# Altium schematic sheet BlazeBus_2.SchDoc
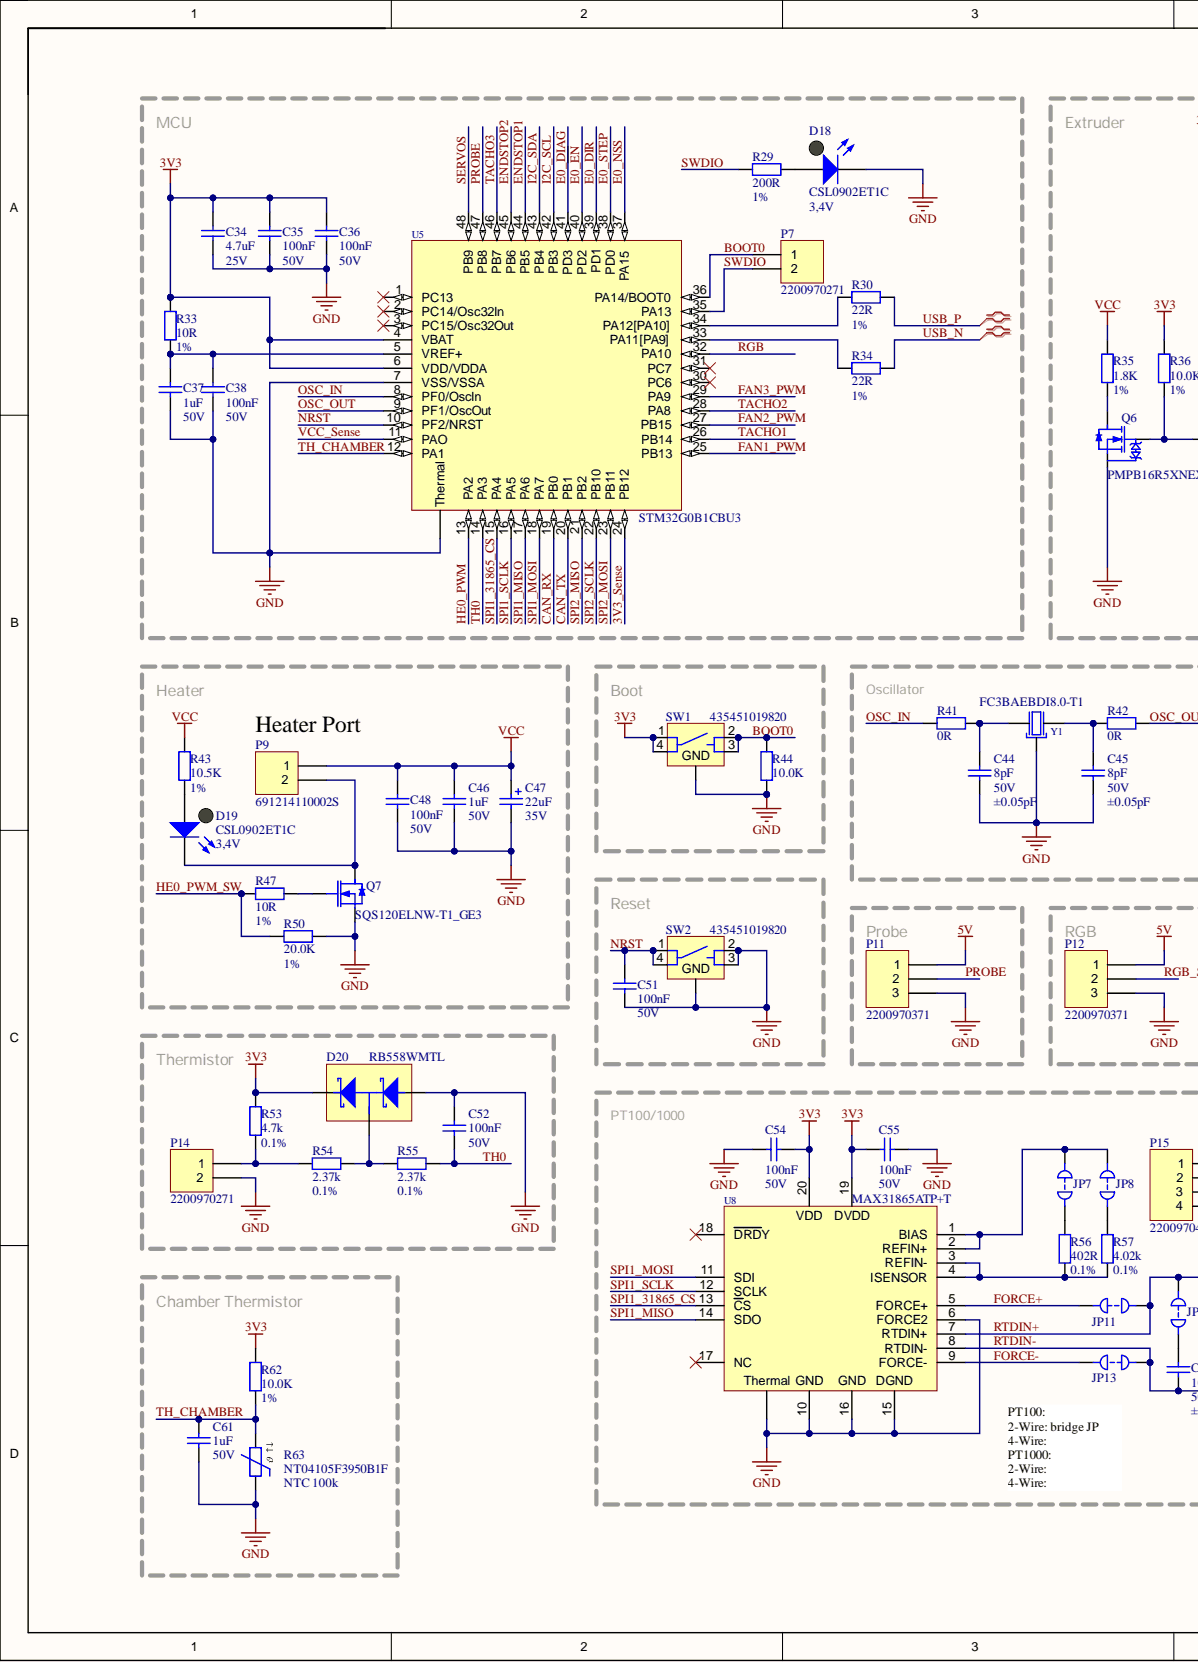
[diagram p0: BlazeBus_2.SchDoc, left]
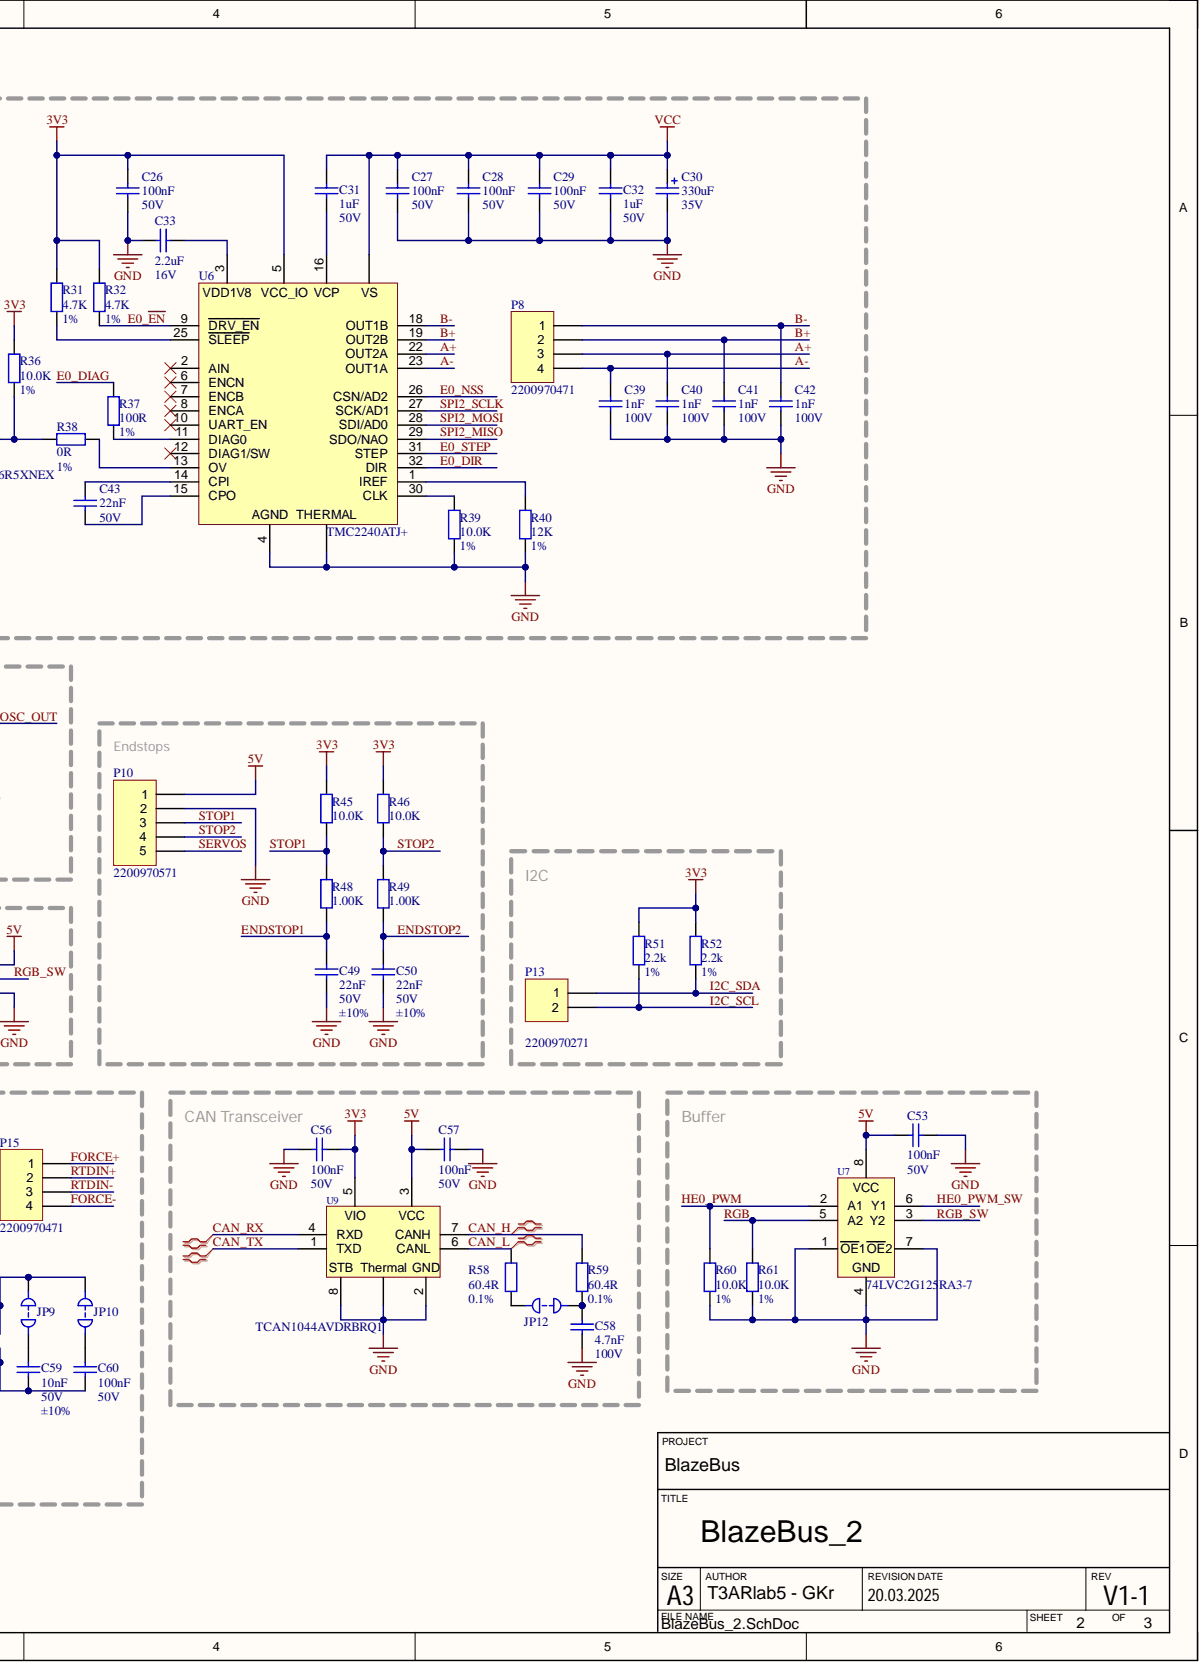
[diagram p1: BlazeBus_2.SchDoc, right]

## Parts
C26  C  value 100nF  Voltage Rating: 50V  [C_0402]
C27  C  value 100nF  Voltage Rating: 50V  [C_0402]
C28  C  value 100nF  Voltage Rating: 50V  [C_0402]
C29  C  value 100nF  Voltage Rating: 50V  [C_0402]
C30  value 330uF  Voltage Rating: 35V  [C_10-11.7_THT]  (hidden comment: C_Pol)
C31  C  value 1uF  Voltage Rating: 50V  [C_0603]
C32  C  value 1uF  Voltage Rating: 50V  [C_0603]
C33  C  value 2.2uF  [C_0603]
C34  C  value 4.7uF  Voltage Rating: 25V  [C_0805]
C35  C  value 100nF  Voltage Rating: 50V  [C_0402]
C36  C  value 100nF  Voltage Rating: 50V  [C_0402]
C37  C  value 1uF  Voltage Rating: 50V  [C_0603]
C38  C  value 100nF  Voltage Rating: 50V  [C_0402]
C39  C  value 1nF  Voltage Rating: 100V  [C_0402]
C40  C  value 1nF  Voltage Rating: 100V  [C_0402]
C41  C  value 1nF  Voltage Rating: 100V  [C_0402]
C42  C  value 1nF  Voltage Rating: 100V  [C_0402]
C43  C  value 22nF  Voltage Rating: 50V  [C_0402]
C44  C  value 8pF  Tolerance: ±0.05pF  Voltage Rating: 50V  [C_0402]
C45  C  value 8pF  Tolerance: ±0.05pF  Voltage Rating: 50V  [C_0402]
C46  C  value 1uF  Voltage Rating: 50V  [C_0805]
C47  value 22uF  Voltage Rating: 35V  [C_5.0-5.8]  (hidden comment: C_Pol)
C48  C  value 100nF  Voltage Rating: 50V  [C_0402]
C49  C  value 22nF  Tolerance: ±10%  Voltage Rating: 50V  [C_0402]
C50  C  value 22nF  Tolerance: ±10%  Voltage Rating: 50V  [C_0402]
C51  C  value 100nF  Voltage Rating: 50V  [C_0402]
C52  C  value 100nF  Voltage Rating: 50V  [C_0402]
C53  C  value 100nF  [C_0402]
C54  C  value 100nF  [C_0402]
C55  C  value 100nF  [C_0402]
C56  C  value 100nF  [C_0402]
C57  C  value 100nF  [C_0402]
C58  C  value 4.7nF  Voltage Rating: 100V  [C_0402]
C59  C  value 10nF  Tolerance: ±10%  Voltage Rating: 50V  [C_0402]
C60  C  value 100nF  Tolerance: ±10%  Voltage Rating: 50V  [C_0402]
C61  C  value 1uF  Voltage Rating: 50V  [C_0603]
D18  Manufacturer ID: CSL0902ET1C  Voltage Rating: 3,4V  [LED_0603_green_Rohm]  (hidden comment: LED_green)
D19  Manufacturer ID: CSL0902ET1C  Voltage Rating: 3,4V  [LED_0603_green_Rohm]  (hidden comment: LED_green)
D20  Manufacturer ID: RB558WMTL  [SOT-490]  (hidden comment: D_Schottky_Dual)
JP7  Jumper  [R_0402 Jumper]  (value not drawn)
JP8  Jumper  [R_0402 Jumper]  (value not drawn)
JP9  Jumper  [R_0402 Jumper]  (value not drawn)
JP10  Jumper  [R_0402 Jumper]  (value not drawn)
JP11  Jumper  [R_0402 Jumper]  (value not drawn)
JP12  Jumper  [R_0402 Jumper]  (value not drawn)
JP13  Jumper  [R_0402 Jumper]  (value not drawn)
P7  Manufacturer ID: 2200970271  [Micro-Lock_Plus-2]  (hidden comment: Header 2)
P8  Manufacturer ID: 2200970471  [Micro-Lock_Plus-4]  (hidden comment: Header 4)
P9  Manufacturer ID: 691214110002S  [WR-TBL-2]  (hidden comment: Header 2)
P10  Manufacturer ID: 2200970571  [Micro-Lock_Plus-5]  (hidden comment: Header 5)
P11  Manufacturer ID: 2200970371  [Micro-Lock_Plus-3]  (hidden comment: Header 3)
P12  Manufacturer ID: 2200970371  [Micro-Lock_Plus-3]  (hidden comment: Header 3)
P13  Manufacturer ID: 2200970271  [Micro-Lock_Plus-2]  (hidden comment: Header 2)
P14  Manufacturer ID: 2200970271  [Micro-Lock_Plus-2]  (hidden comment: Header 2)
P15  Manufacturer ID: 2200970471  [Micro-Lock_Plus-4]  (hidden comment: Header 4)
Q6  Manufacturer ID: PMPB16R5XNEX  [DFN2020M-6]  (hidden comment: MOSFET-N_GDS)
Q7  Manufacturer ID: SQS120ELNW-T1_GE3  [PowerPAK-1212-8SLW]  (hidden comment: MOSFET-N-Depletion-SSSGDDDD)
R29  R  value 200R  Tolerance: 1%  [R_0402]
R30  R  value 22R  Tolerance: 1%  [R_0402]
R31  R  value 4.7K  Tolerance: 1%  [R_0402]
R32  R  value 4.7K  Tolerance: 1%  [R_0402]
R33  R  value 10R  Tolerance: 1%  [R_0402]
R34  R  value 22R  Tolerance: 1%  [R_0402]
R35  R  value 1.8K  Tolerance: 1%  [R_0805]
R36  R  value 10.0K  Tolerance: 1%  [R_0402]
R37  R  value 100R  Tolerance: 1%  [R_0402]
R38  R  value 0R  [R_0402]
R39  R  value 10.0K  Tolerance: 1%  [R_0402]
R40  R  value 12K  Tolerance: 1%  [R_0402]
R41  R  value 0R  [R_0402]
R42  R  value 0R  [R_0402]
R43  R  value 10.5K  Tolerance: 1%  [R_0402]
R44  R  value 10.0K  [R_0402]
R45  R  value 10.0K  [R_0402]
R46  R  value 10.0K  [R_0402]
R47  R  value 10R  [R_0402]
R48  R  value 1.00K  [R_0402]
R49  R  value 1.00K  [R_0402]
R50  R  value 20.0K  Tolerance: 1%  [R_0402]
R51  R  value 2.2k  Tolerance: 1%  [R_0402]
R52  R  value 2.2k  Tolerance: 1%  [R_0402]
R53  R  value 4.7k  Tolerance: 0.1%  [R_0402]
R54  R  value 2.37k  Tolerance: 0.1%  [R_0402]
R55  R  value 2.37k  Tolerance: 0.1%  [R_0402]
R56  R  value 402R  Tolerance: 0.1%  [R_0402]
R57  R  value 4.02k  Tolerance: 0.1%  [R_0402]
R58  R  value 60.4R  [R_0402]
R59  R  value 60.4R  Tolerance: 0.1%  [R_0402]
R60  R  value 10.0K  Tolerance: 1%  [R_0402]
R61  R  value 10.0K  Tolerance: 1%  [R_0402]
R62  R  value 10.0K  Tolerance: 1%  [R_0402]
R63  R  Manufacturer ID: NT04105F3950B1F  [R_0402]
SW1  Switch-1x NO  [435451019820]  (value not drawn)
SW2  Switch-1x NO  [435451019820]  (value not drawn)
U5  STM32G0B1C  Manufacturer ID: STM32G0B1CBU3  [UFQFPN48]
U6  TMC2240  Manufacturer ID: TMC2240ATJ+  [TQFN-32]
U7  74LVC2G125  Manufacturer ID: 74LVC2G125RA3-7  [DFN1210-8]
U8  MAX31865  Manufacturer ID: MAX31865ATP+T  [TQFN-20]
U9  TCAN1044AV  Manufacturer ID: TCAN1044AVDRBRQ1  [SON-8]
Y1  Manufacturer ID: FC3BAEBDI8.0-T1  [4-SMD 3.2x2.5-Abracon]  (hidden comment: Crystal 4-Pin)

## Nets
3V3: C26.2 C34.2 C35.2 C36.2 C54.2 C55.1 C56.2 D20.2 R31.2 R32.2 R33.2 R36.2 R45.2 R46.2 R51.2 R52.2 R53.2 R62.2 SW1.1 SW1.4 U5.4(VBAT) U5.6(VDD/VDDA) U6.5 U8.19 U8.20 U9.5
5V: C53.2 C57.2 P10.1 P11.1 P12.1 U7.8 U9.3
GND: C26.1 C27.1 C28.1 C29.1 C30.2 C32.1 C33.1 C34.1 C35.1 C36.1 C37.1 C38.1 C39.1 C40.1 C41.1 C42.1 C44.1 C45.1 C46.1 C47.2 C48.1 C49.1 C50.1 C51.1 C52.2 C53.1 C54.1 C55.2 C56.1 C57.1 C58.1 C61.1 D18.K D20.1 P10.2 P11.3 P12.3 P14.2 Q6.4,8 Q7.1,2,3 R39.2 R40.1 R44.1 R50.2 R60.1 R61.2 R63.1 SW1.5 SW2.2 SW2.3 SW2.5 U5.7(VSS/VSSA) U5.Thermal U6.4 U6.THERMAL U7.1(OE1) U7.4 U7.7(OE2) U8.6(FORCE2) U8.10 U8.15 U8.16 U8.Thermal U9.2 U9.8 U9.Thermal Y1.2,4
VCC: C27.2 C28.2 C29.2 C30.1 C31.2 C32.2 C46.2 C47.1 C48.2 P9.1 R35.2 R43.2 U6.17,20,21,24(VS)
3V3_Sense: U5.24(PB12)
A+: C40.2 P8.3 U6.22(OUT2A)
A-: C39.2 P8.4 U6.23(OUT1A)
B+: C41.2 P8.2 U6.19(OUT2B)
B-: C42.2 P8.1 U6.18(OUT1B)
BOOT0: P7.1 R44.2 SW1.2 SW1.3 U5.36(PA14/BOOT0)
CAN_H: R59.1 U9.7(CANH)
CAN_L: R58.1 U9.6(CANL)
CAN_RX: U5.19(PB0) U9.4(RXD)
CAN_TX: U5.20(PB1) U9.1(TXD)
E0_DIAG: R37.1 U5.41(PD3)
E0_DIR: U5.39(PD1) U6.32(DIR)
E0_~{EN}: R32.1 U5.40(PD2) U6.9(DRV_EN)
E0_NSS: U5.37(PA15) U6.26(CSN/AD2)
E0_STEP: U5.38(PD0) U6.31(STEP)
ENDSTOP1: C49.2 R48.1 U5.44(PB5)
ENDSTOP2: C50.2 R49.1 U5.45(PB6)
FAN1_PWM: U5.25(PB13)
FAN2_PWM: U5.27(PB15)
FAN3_PWM: U5.29(PA9)
FORCE+: JP11.2 P15.1 U8.5(FORCE+)
FORCE-: JP13.1 P15.4 U8.9(FORCE-)
HE0_PWM: R60.2 U5.13(PA2) U7.2(A1)
HE0_PWM_SW: R47.1 R50.1 U7.6(Y1)
I2C_SCL: P13.2 R51.1 U5.42(PB3)
I2C_SDA: P13.1 R52.1 U5.43(PB4)
NRST: C51.2 SW2.1 SW2.4 U5.10(PF2/NRST)
OSC_IN: R41.1 U5.8(PF0/OscIn)
OSC_OUT: R42.2 U5.9(PF1/OscOut)
PROBE: P11.2 U5.47(PB8)
RGB: R61.1 U5.32(PA10) U7.5(A2)
RGB_SW: P12.2 U7.3(Y2)
RTDIN+: JP9.2 JP10.2 JP11.1 P15.2 U8.7(RTDIN+)
RTDIN-: C59.1 C60.1 JP13.2 P15.3 U8.8(RTDIN-)
SERVOS: P10.5 U5.48(PB9)
SPI1_31865_CS: U5.15(PA4) U8.13(CS)
SPI1_MISO: U5.17(PA6) U8.14(SDO)
SPI1_MOSI: U5.18(PA7) U8.11(SDI)
SPI1_SCLK: U5.16(PA5) U8.12(SCLK)
SPI2_MISO: U5.21(PB2) U6.29(SDO/NAO)
SPI2_MOSI: U5.23(PB11) U6.28(SDI/AD0)
SPI2_SCLK: U5.22(PB10) U6.27(SCK/AD1)
STOP1: P10.3 R45.1 R48.2
STOP2: P10.4 R46.1 R49.2
SWDIO: P7.2 R29.2 U5.35(PA13)
TACHO1: U5.26(PB14)
TACHO2: U5.28(PA8)
TACHO3: U5.46(PB7)
TH0: C52.1 R55.2 U5.14(PA3)
TH_CHAMBER: C61.2 R62.1 R63.2 U5.12(PA1)
USB_N: R34.2
USB_P: R30.2
VCC_Sense: U5.11(PAO)
NetC31_1: C31.1 U6.16
NetC33_2: C33.2 U6.3
NetC37_2: C37.2 C38.2 R33.1 U5.5(VREF+)
NetC43_1: C43.1 U6.14(CPI)
NetC43_2: C43.2 U6.15(CPO)
NetC44_2: C44.2 R41.2 Y1.1
NetC45_2: C45.2 R42.1 Y1.3
NetC58_2: C58.2 JP12.2 R59.2
NetC59_2: C59.2 JP9.1
NetC60_2: C60.2 JP10.1
NetD18_A: D18.A R29.1
NetD19_A: D19.A R43.1
NetD19_K: D19.K P9.2 Q7.5,6,7,8
NetD20_3: D20.3 R54.2 R55.1
NetJP7_1: JP7.1 R56.2
NetJP7_2: JP7.2 JP8.2 U8.1(BIAS) U8.2(REFIN+)
NetJP8_1: JP8.1 R57.2
NetJP12_1: JP12.1 R58.2
NetP14_1: P14.1 R53.1 R54.1
NetQ6_1,2,5,6,7: Q6.1,2,5,6,7 R35.1
NetQ6_3: Q6.3 R36.1 R38.1
NetQ7_4: Q7.4 R47.2
NetR30_1: R30.1 U5.34(PA12[PA10])
NetR31_1: R31.1 U6.25(SLEEP)
NetR34_1: R34.1 U5.33(PA11[PA9])
NetR37_2: R37.2 U6.11(DIAG0)
NetR38_2: R38.2 U6.13(OV)
NetR39_1: R39.1 U6.30(CLK)
NetR40_2: R40.2 U6.1(IREF)
NetR56_1: R56.1 R57.1 U8.3(REFIN-) U8.4(ISENSOR)

Not connected: U5 1(PC13) 2(PC14/Osc32In) 3(PC15/Osc32Out) 30(PC6) 31(PC7); U6 2(AIN) 6(ENCN) 7(ENCB) 8(ENCA) 10(UART_EN) 12(DIAG1/SW); U8 17(NC) 18(DRDY)

## Library data of the parts
C26-C29 C35 C36 C38 C48 C51-C57 C60 = 02_0122: Capacitor 100nF 50V ±10% 0402 Ceramic SMD; Manufacturer: Yageo; Database Table Name: 02_Capacitors$; Temperature Coefficient: X7R; Component Kind: Standard; Library Name: Library_DBLib.DbLib; Mounting Style: SMD; Part ID: 02_0122; Temperature Range: -55°C to +125°C; Type: Ceramic
  C26-C29 C35 C36 C38 C48 C51 C52: Tolerance: ±10%
  C53-C57: Tolerance: ±10%; Voltage Rating: 50V
C30 = 02_0937: Capacitor 330uF 35V ±20% 10-11.7_THT Aluminium Polymer THT; Manufacturer: Panasonic; Manufacturer ID: EEH-AZS1V331B; Database Table Name: 02_Capacitors$; Component Kind: Standard; Digikey ID: 10-EEH-AZS1V331BCT-ND; LCSC ID: C7182683; Library Name: Library_DBLib.DbLib; Mounting Style: THT; Mouser ID: 667-EEH-AZS1V331B; Part ID: 02_0937; Revision: July-2002: Re-released for DXP Platform.; Signal Integrity: Cap Pol; Simulation: CAP; Temperature Range: -55°C to +135°C; Tolerance: ±20%; Type: Aluminium Polymer
C31 C32 C37 C61 = 02_0270: Capacitor 1uF 50V ±10% 0603 Ceramic SMD; Manufacturer: Yageo; Database Table Name: 02_Capacitors$; Temperature Coefficient: X7R; Component Kind: Standard; Library Name: Library_DBLib.DbLib; Mounting Style: SMD; Part ID: 02_0270; Temperature Range: -55°C to +125°C; Tolerance: ±10%; Type: Ceramic
C33 = 02_0185: Capacitor 2.2uF 16V ±10% 0603 Ceramic SMD; Manufacturer: Yageo; Database Table Name: 02_Capacitors$; Temperature Coefficient: X7R; Component Kind: Standard; Library Name: Library_DBLib.DbLib; Mounting Style: SMD; Part ID: 02_0185; Temperature Range: -55°C to +125°C; Tolerance: ±10%; Type: Ceramic; Voltage Rating: 16V
C34 = 02_0375: Capacitor 4.7uF 25V ±10% 0805 Ceramic SMD; Manufacturer: Yageo; Database Table Name: 02_Capacitors$; Temperature Coefficient: X7R; Component Kind: Standard; Library Name: Library_DBLib.DbLib; Mounting Style: SMD; Part ID: 02_0375; Temperature Range: -55°C to +125°C; Tolerance: ±10%; Type: Ceramic
C39-C42 = 02_0127: Capacitor 1nF 100V ±10% 0402 Ceramic SMD; Manufacturer: Yageo; Database Table Name: 02_Capacitors$; Temperature Coefficient: X7R; Component Kind: Standard; Library Name: Library_DBLib.DbLib; Mounting Style: SMD; Part ID: 02_0127; Temperature Range: -55°C to +125°C; Tolerance: ±10%; Type: Ceramic
C43 C49 C50 = 02_0117: Capacitor 22nF 50V ±10% 0402 Ceramic SMD; Manufacturer: Yageo; Database Table Name: 02_Capacitors$; Temperature Coefficient: X7R; Component Kind: Standard; Library Name: Library_DBLib.DbLib; Mounting Style: SMD; Part ID: 02_0117; Temperature Range: -55°C to +125°C; Type: Ceramic
  C43: Tolerance: ±10%
C44 C45 = 02_0938: Capacitor 8pF 50V ±0.05pF 0402 Ceramic SMD; Manufacturer: Yageo; Manufacturer ID: CQ0402ARNPO9BN8R0; Database Table Name: 02_Capacitors$; Temperature Coefficient: NP0; Component Kind: Standard; Digikey ID: 13-CQ0402ARNPO9BN8R0CT-ND; LCSC ID: C3849295; Library Name: Library_DBLib.DbLib; Mounting Style: SMD; Mouser ID: 603-CQ402ARNPO9BN8R0; Part ID: 02_0938; Temperature Range: -55°C to +125°C; Type: Ceramic
C46 = 02_0424: Capacitor 1uF 50V ±10% 0805 Ceramic SMD; Manufacturer: Yageo; Database Table Name: 02_Capacitors$; Temperature Coefficient: X7R; Component Kind: Standard; Library Name: Library_DBLib.DbLib; Mounting Style: SMD; Part ID: 02_0424; Temperature Range: -55°C to +125°C; Tolerance: ±10%; Type: Ceramic
C47 = 02_0936: Capacitor 22uF 35V ±20% 5.0-5.8 Aluminium Polymer SMD; Manufacturer: Panasonic; Manufacturer ID: EEH-ZC1V220R; Database Table Name: 02_Capacitors$; Component Kind: Standard; Digikey ID: P15471CT-ND; LCSC ID: C341197; Library Name: Library_DBLib.DbLib; Mounting Style: SMD; Mouser ID: 667-EEH-ZC1V220R; Part ID: 02_0936; Revision: July-2002: Re-released for DXP Platform.; Signal Integrity: Cap Pol; Simulation: CAP; Temperature Range: -55°C to +125°C; Tolerance: ±20%; Type: Aluminium Polymer
C58 = 02_0129: Capacitor 4.7nF 100V ±10% 0402 Ceramic SMD; Manufacturer: Yageo; Database Table Name: 02_Capacitors$; Temperature Coefficient: X7R; Component Kind: Standard; Library Name: Library_DBLib.DbLib; Mounting Style: SMD; Part ID: 02_0129; Temperature Range: -55°C to +125°C; Tolerance: ±10%; Type: Ceramic
C59 = 02_0113: Capacitor 10nF 50V ±10% 0402 Ceramic SMD; Manufacturer: Yageo; Database Table Name: 02_Capacitors$; Temperature Coefficient: X7R; Component Kind: Standard; Library Name: Library_DBLib.DbLib; Mounting Style: SMD; Part ID: 02_0113; Temperature Range: -55°C to +125°C; Type: Ceramic
D18 D19 = 04_0004: LED SMD Green 1.2cd@20mA 0603; Manufacturer: Rohm; Current Rating: 30mA; Database Table Name: 04_Diodes$; Power Rating: 114mW; Type: LED Green; Component Kind: Standard; Digikey ID: 846-CSL0902ET1CCT-ND; LCSC ID: C5603524; Library Name: Library_DBLib.DbLib; Mouser ID: 755-CSL0902ET1C; Part ID: 04_0004; Temperature Range: -40°C to +110°C
D20 = 04_0010: Schottky Array; Manufacturer: Rohm; Code - JEDEC: DO-214-AA; Current Rating: 100mA, 0.5A Peak; Database Table Name: 04_Diodes$; Voltage Rating: 30V; Component Kind: Standard; Digikey ID: 846-RB558WMTLCT-ND; LCSC ID: C509944; Library Name: Library_DBLib.DbLib; Mouser ID: 755-RB558WMTL; Part ID: 04_0010; Published: 8-Jun-2000; Revision: July-2002: Re-released for DXP Platform.; Signal Integrity: D Schottky; Simulation: SKYDIODE; Temperature Range: -40°C to +125°C; Type: Schottky Array; Publisher: Altium Limited
JP7-JP13 = 07_0026: 0402 Jumper, solderable; Database Table Name: 07_Connectors$; Component Kind: Standard; Library Name: Library_DBLib.DbLib; Part ID: 07_0026; Type: 0402 Jumper, solderable
P7 P13 P14 = 07_0022: Micro-Lock Plus, 2Pin, THT, 1.50mm Pitch, Tin-Bismuth; Manufacturer: Molex; Current Rating: 3,3A; Database Table Name: 07_Connectors$; Voltage Rating: 50V; Component Kind: Standard; Digikey ID: 900-2200970271-ND; Library Name: Library_DBLib.DbLib; Mounting Style: THT; Mouser ID: 538-220097-0271; Orientation: Vertical; Part ID: 07_0022; Pitch: 1.50mm; Revision: 17-Jul-2002: Re-released for DXP Platform.; Signal Integrity: Connector; Temperature Range: -40°C to +105°C; Type: 2Pin Micro-Lock Plus Header; Publisher: Altium Limited
P8 P15 = 07_0024: Micro-Lock Plus, 4Pin, THT, 1.50mm Pitch, Tin-Bismuth; Manufacturer: Molex; Current Rating: 3,3A; Database Table Name: 07_Connectors$; Voltage Rating: 50V; Component Kind: Standard; Digikey ID: 900-2200970471-ND; Library Name: Library_DBLib.DbLib; Mounting Style: THT; Mouser ID: 538-220097-0471; Orientation: Vertical; Part ID: 07_0024; Pitch: 1.50mm; Revision: 17-Jul-2002: Re-released for DXP Platform.; Signal Integrity: Connector; Temperature Range: -40°C to +105°C; Type: 4Pin Micro-Lock Plus Header; Publisher: Altium Limited
P9 = 07_0012: WR-TBL, 2Pin, THT, 3.50mm Pitch; Manufacturer: Würth; Current Rating: 10A; Database Table Name: 07_Connectors$; Voltage Rating: 300V; Component Kind: Standard; Digikey ID: 732-691214110002S-ND; Library Name: Library_DBLib.DbLib; Mounting Style: THT; Mouser ID: 710-691214110002S; Orientation: Horizontal; Part ID: 07_0012; Pitch: 3.50mm; Revision: 17-Jul-2002: Re-released for DXP Platform.; Signal Integrity: Connector; Temperature Range: -30°C to +120°C; Type: 2Pin WR-TBL Screw Terminal; Publisher: Altium Limited
P10 = 07_0029: Micro-Lock Plus, 5Pin, THT, 1.50mm Pitch, Tin-Bismuth; Manufacturer: Molex; Current Rating: 3,3A; Database Table Name: 07_Connectors$; Voltage Rating: 50V; Component Kind: Standard; Digikey ID: 900-2200970571-ND; LCSC ID: C19803171; Library Name: Library_DBLib.DbLib; Mounting Style: THT; Mouser ID: 538-220097-0571; Orientation: Vertical; Part ID: 07_0029; Pitch: 1.50mm; Revision: 17-Jul-2002: Re-released for DXP Platform.; Signal Integrity: Connector; Temperature Range: -40°C to +105°C; Type: 5Pin Micro-Lock Plus Header; Publisher: Altium Limited
P11 P12 = 07_0023: Micro-Lock Plus, 3Pin, THT, 1.50mm Pitch, Tin-Bismuth; Manufacturer: Molex; Current Rating: 3,3A; Database Table Name: 07_Connectors$; Voltage Rating: 50V; Component Kind: Standard; Library Name: Library_DBLib.DbLib; Mounting Style: THT; Mouser ID: 538-220097-0371; Orientation: Vertical; Part ID: 07_0023; Pitch: 1.50mm; Revision: 17-Jul-2002: Re-released for DXP Platform.; Signal Integrity: Connector; Temperature Range: -40°C to +105°C; Type: 3Pin Micro-Lock Plus Header; Publisher: Altium Limited
Q6 = 05_0002: N-Channel Trench 30V 7A 1.7W; Manufacturer: Nexperia; Database Table Name: 05_Transistors$; Power Rating: 7.7W; Type: N-Mosfet; Component Kind: Standard; Current Rating: 7A; Digikey ID: 1727-PMPB16R5XNEXCT-ND; LCSC ID: C3277699; Library Name: Library_DBLib.DbLib; Mouser ID: 771-PMPB16R5XNEX; Part ID: 05_0002; Revision: July-2002: Re-released for DXP Platform.; Simulation: NMOS; Temperature Range: -55°C to +150°C; Voltage Rating: 30V
Q7 = 05_0001: N-Channel 30V 110A 39W; Manufacturer: Vishay; Database Table Name: 05_Transistors$; Type: N-Mosfet; Component Kind: Standard; Current Rating: 110A; Digikey ID: 742-SQS120ELNW-T1_GE3CT-ND; Library Name: Library_DBLib.DbLib; Mouser ID: 78-SQS120ELNW-T1_GE3; Part ID: 05_0001; Power Rating: 39W; Revision: July-2002: Re-released for DXP Platform.; Temperature Range: -55°C to +175°C; Voltage Rating: 30V
R29 = 01_0223: Resistor 200R 0402 1/16W 1%; Manufacturer: Yageo; Manufacturer ID: RC0402FR-07200RL; Current Rating: 1A; Database Table Name: 01_Resistors$; Temperature Coefficient: ± 100ppm/℃; Voltage Rating: 50V; Component Kind: Standard; Library Name: Library_DBLib.DbLib; Mouser ID: 603-RC0402FR-07200RL; Part ID: 01_0223; Power Rating: 1/16W; Temperature Range: -55°C to +155°C
R30 R34 = 01_2705: Resistor 22R 0402 1/16W 1%; Manufacturer: Yageo; Manufacturer ID: RC0402FR-0722RL; Current Rating: 1A; Database Table Name: 01_Resistors$; Temperature Coefficient: ± 100ppm/℃; Voltage Rating: 50V; Component Kind: Standard; Digikey ID: 311-22.0LRCT-ND; LCSC ID: C114765; Library Name: Library_DBLib.DbLib; Mouser ID: 603-RC0402FR-0722RL; Part ID: 01_2705; Power Rating: 1/16W; Temperature Range: -55°C to +155°C
R31 R32 = 01_2723: Resistor 4.7K 0402 1/16W 1%; Manufacturer: Yageo; Manufacturer ID: RC0402FR-074K7L; Current Rating: 1A; Database Table Name: 01_Resistors$; Temperature Coefficient: ± 100ppm/℃; Voltage Rating: 50V; Component Kind: Standard; Library Name: Library_DBLib.DbLib; Mouser ID: 603-RC0402FR-074K7L; Part ID: 01_2723; Power Rating: 1/16W; Temperature Range: -55°C to +155°C
R33 R47 = 01_0098: Resistor 10R 0402 1/16W 1%; Manufacturer: Yageo; Manufacturer ID: RC0402FR-0710RL; Current Rating: 1A; Database Table Name: 01_Resistors$; Temperature Coefficient: ± 200ppm/℃; Voltage Rating: 50V; Component Kind: Standard; Library Name: Library_DBLib.DbLib; Mouser ID: 603-RC0402FR-0710RL; Part ID: 01_0098; Power Rating: 1/16W; Temperature Range: -55°C to +155°C
  R47: Tolerance: 1%
R35 = 01_2851: Resistor 1.8K 0805 1/2W 1%; Manufacturer: Panasonic; Manufacturer ID: ERJ-P06F1801V; Database Table Name: 01_Resistors$; Temperature Coefficient: ± 100ppm/℃; Voltage Rating: 400V; Component Kind: Standard; Digikey ID: 10-ERJ-P06F1801VCT-ND; LCSC ID: C416985; Library Name: Library_DBLib.DbLib; Mouser ID: 667-ERJ-P06F1801V; Part ID: 01_2851; Power Rating: 1/2W; Temperature Range: -55°C to +155°C
R36 R39 R44-R46 R60-R62 = 01_0386: Resistor 10.0K 0402 1/16W 1%; Manufacturer: Yageo; Manufacturer ID: RC0402FR-0710KL; Current Rating: 1A; Database Table Name: 01_Resistors$; Temperature Coefficient: ± 100ppm/℃; Voltage Rating: 50V; Component Kind: Standard; Library Name: Library_DBLib.DbLib; Mouser ID: 603-RC0402FR-0710KL; Part ID: 01_0386; Power Rating: 1/16W; Temperature Range: -55°C to +155°C
  R44-R46: Tolerance: 1%
R37 = 01_0194: Resistor 100R 0402 1/16W 1%; Manufacturer: Yageo; Manufacturer ID: RC0402FR-07100RL; Current Rating: 1A; Database Table Name: 01_Resistors$; Temperature Coefficient: ± 100ppm/℃; Voltage Rating: 50V; Component Kind: Standard; Library Name: Library_DBLib.DbLib; Mouser ID: 603-RC0402FR-07100RL; Part ID: 01_0194; Power Rating: 1/16W; Temperature Range: -55°C to +155°C
R38 R41 R42 = 01_0001: Resistor 0R 0402 1/16W 1%; Manufacturer: Yageo; Manufacturer ID: RC0402FR-070RL; Current Rating: 1A; Database Table Name: 01_Resistors$; Temperature Coefficient: ± 200ppm/℃; Voltage Rating: 50V; Component Kind: Standard; Library Name: Library_DBLib.DbLib; Mouser ID: 603-RC0402FR-070RL; Part ID: 01_0001; Power Rating: 1/16W; Temperature Range: -55°C to +155°C; Tolerance: 1%
R40 = 01_2847: Resistor 12K 0402 1/16W 1%; Manufacturer: Yageo; Manufacturer ID: RC0402FR-0712KL; Current Rating: 1A; Database Table Name: 01_Resistors$; Temperature Coefficient: ± 100ppm/℃; Voltage Rating: 50V; Component Kind: Standard; Library Name: Library_DBLib.DbLib; Mouser ID: 603-RC0402FR-0712KL; Part ID: 01_2847; Power Rating: 1/16W; Temperature Range: -55°C to +155°C
R43 = 01_0388: Resistor 10.5K 0402 1/16W 1%; Manufacturer: Yageo; Manufacturer ID: RC0402FR-0710K5L; Current Rating: 1A; Database Table Name: 01_Resistors$; Temperature Coefficient: ± 100ppm/℃; Voltage Rating: 50V; Component Kind: Standard; Library Name: Library_DBLib.DbLib; Mouser ID: 603-RC0402FR-0710K5L; Part ID: 01_0388; Power Rating: 1/16W; Temperature Range: -55°C to +155°C
R48 R49 = 01_0290: Resistor 1.00K 0402 1/16W 1%; Manufacturer: Yageo; Manufacturer ID: RC0402FR-071KL; Current Rating: 1A; Database Table Name: 01_Resistors$; Temperature Coefficient: ± 100ppm/℃; Voltage Rating: 50V; Component Kind: Standard; Library Name: Library_DBLib.DbLib; Mouser ID: 603-RC0402FR-071KL; Part ID: 01_0290; Power Rating: 1/16W; Temperature Range: -55°C to +155°C; Tolerance: 1%
R50 = 01_0415: Resistor 20.0K 0402 1/16W 1%; Manufacturer: Yageo; Manufacturer ID: RC0402FR-0720KL; Current Rating: 1A; Database Table Name: 01_Resistors$; Temperature Coefficient: ± 100ppm/℃; Voltage Rating: 50V; Component Kind: Standard; Library Name: Library_DBLib.DbLib; Mouser ID: 603-RC0402FR-0720KL; Part ID: 01_0415; Power Rating: 1/16W; Temperature Range: -55°C to +155°C
R51 R52 = 01_2706: Resistor 2.2k 0402 1/16W 1%; Manufacturer: Yageo; Manufacturer ID: RC0402FR-072K2L; Current Rating: 1A; Database Table Name: 01_Resistors$; Temperature Coefficient: ± 100ppm/℃; Voltage Rating: 50V; Component Kind: Standard; Digikey ID: 311-2.20KLRCT-ND; LCSC ID: C114762; Library Name: Library_DBLib.DbLib; Mouser ID: 603-RC0402FR-072K2L; Part ID: 01_2706; Power Rating: 1/16W; Temperature Range: -55°C to +155°C
R53 = 01_2701: Resistor 4.7k 0402 1/16W 0.1%; Manufacturer: Yageo; Manufacturer ID: RT0402BRD074K7L; Database Table Name: 01_Resistors$; Temperature Coefficient: ± 25ppm/℃; Voltage Rating: 50V; Component Kind: Standard; Digikey ID: YAG1446CT-ND; Library Name: Library_DBLib.DbLib; Mouser ID: 603-RT0402BRD074K7L; Part ID: 01_2701; Power Rating: 1/16W; Temperature Range: -55°C to +155°C
R54 R55 = 01_2700: Resistor 2.37k 0402 1/16W 0.1%; Manufacturer: Yageo; Manufacturer ID: RT0402BRD072K37L; Database Table Name: 01_Resistors$; Temperature Coefficient: ± 25ppm/℃; Voltage Rating: 50V; Component Kind: Standard; Digikey ID: YAG4229CT-ND; Library Name: Library_DBLib.DbLib; Mouser ID: 603-RT0402BRD072K37L; Part ID: 01_2700; Power Rating: 1/16W; Temperature Range: -55°C to +155°C
R56 = 01_2703: Resistor 402R 0402 1/16W 0.1%; Manufacturer: Yageo; Manufacturer ID: RT0402BRD07402RL; Database Table Name: 01_Resistors$; Temperature Coefficient: ± 25ppm/℃; Voltage Rating: 50V; Component Kind: Standard; Digikey ID: YAG4260CT-ND; Library Name: Library_DBLib.DbLib; Mouser ID: 603-RT0402BRD07402RL; Part ID: 01_2703; Power Rating: 1/16W; Temperature Range: -55°C to +155°C
R57 = 01_2702: Resistor 4.02k 0402 1/16W 0.1%; Manufacturer: Yageo; Manufacturer ID: RT0402BRD074K02L; Database Table Name: 01_Resistors$; Temperature Coefficient: ± 25ppm/℃; Voltage Rating: 50V; Component Kind: Standard; Digikey ID: YAG4271CT-ND; Library Name: Library_DBLib.DbLib; Mouser ID: 603-RT0402BRD074K02L; Part ID: 01_2702; Power Rating: 1/16W; Temperature Range: -55°C to +155°C
R58 R59 = 01_2697: Resistor 60.4R 0402 1/16W 0.1%; Manufacturer: Yageo; Manufacturer ID: RT0402BRD0760R4L; Database Table Name: 01_Resistors$; Temperature Coefficient: ± 25ppm/℃; Voltage Rating: 50V; Component Kind: Standard; Library Name: Library_DBLib.DbLib; Mouser ID: 603-RT0402BRD0760R4L; Part ID: 01_2697; Power Rating: 1/16W; Temperature Range: -55°C to +155°C
  R58: Tolerance: 0.1%
R63 = 08_0006: NTC 3950 100k 1% 0402; Manufacturer: Eaton; Database Table Name: 08_Misc$; Power Rating: 100mW; Component Kind: Standard; Digikey ID: 283-NT04105F3950B1FCT-ND; LCSC ID: C17574653; Library Name: Library_DBLib.DbLib; Mouser ID: 504-NT04105F3950B1F; Part ID: 08_0006; Temperature Range: -40°C to +125°C; Tolerance: 1%; Type: NTC 100k
SW1 SW2 = 08_0005: Tactile Switch 32V 50mA SMD; Manufacturer: Würth; Database Table Name: 08_Misc$; Component Kind: Standard; Current Rating: 50mA; Digikey ID: 732-435451019820CT-ND; Library Name: Library_DBLib.DbLib; Manufacturer ID: 435451019820; Mouser ID: 710-435451019820; Part ID: 08_0005; Temperature Range: -55°C to +125°C; Type: Switch; Voltage Rating: 32V
U5 = 06_0002: ARM MCU 32bit, 64MHz, 144kB RAM, 128kB Flash, 44 IOs; Manufacturer: STMicroelectronics; Current Rating: 100mA; Database Table Name: 06_ICs$; Voltage Rating: 2.4V to 3.6V; Component Kind: Standard; Library Name: Library_DBLib.DbLib; Mouser ID: 511-STM32G0B1CBU3; Part ID: 06_0002; Temperature Range: -40°C to +125°C; Type: Microcontroller
U6 = 06_0010: Bipolar Motor Driver SPI/UART; Manufacturer: Analog Devices; Current Rating: 2.1A, 5A peak; Database Table Name: 06_ICs$; Voltage Rating: 4.5V to 36V; Component Kind: Standard; Digikey ID: 505-TMC2240ATJ+-ND; LCSC ID: C7429724; Library Name: Library_DBLib.DbLib; Mouser ID: 700-TMC2240ATJ+; Part ID: 06_0010; Temperature Range: -40°C to +125°C; Type: Motor Driver
U7 = 06_0008: Non-Inverting Buffer, 2-Channel, 3-State, 8DFN; Manufacturer: Diodes Inc.; Current Rating: ±32mA; Database Table Name: 06_ICs$; Voltage Rating: 1.65V to 5.5V; Component Kind: Standard; Digikey ID: 74LVC2G125RA3-7DICT-ND; LCSC ID: C842614; Library Name: Library_DBLib.DbLib; Mouser ID: 621-74LVC2G125RA3-7; Part ID: 06_0008; Temperature Range: -40°C to +125°C; Type: Buffer-Switch
U8 = 06_0006: RTD Sensor, PT1000/PT100, 15bit, SPI, TQFN; Manufacturer: Analog Devices; Database Table Name: 06_ICs$; Voltage Rating: 3V to 3.6V; Component Kind: Standard; Digikey ID: MAX31865ATP+TCT-ND; LCSC ID: C118474; Library Name: Library_DBLib.DbLib; Mouser ID: 700-MAX31865ATP+T; Part ID: 06_0006; Temperature Range: -40°C to +125°C; Type: RTD Sensor
U9 = 06_0005: CAN-FD Transceiver, 8Mb/s, IO-Voltage, SON-8; Manufacturer: Texas Instruments; Database Table Name: 06_ICs$; Voltage Rating: 4.5V to 5.5V; Component Kind: Standard; Digikey ID: 296-TCAN1044AVDRBRQ1CT-ND; LCSC ID: C3234119; Library Name: Library_DBLib.DbLib; Mouser ID: 595-TCAN1044AVDRBRQ1; Part ID: 06_0005; Temperature Range: -40°C to +150°C; Type: CAN Transceiver
Y1 = 08_0007: Crystal 8MHz 8pF SMD; Manufacturer: Abracon; Database Table Name: 08_Misc$; Temperature Coefficient: ± 50ppm; Component Kind: Standard; Digikey ID: 631-FC3BAEBDI8.0-T1CT-ND; Library Name: Library_DBLib.DbLib; Mouser ID: 815-FC3BAEBDI8.0-T1; Part ID: 08_0007; Temperature Range: -40°C to +125°C; Type: Crystal
